# Altium schematic sheet CC3100_click_v100.SchDoc
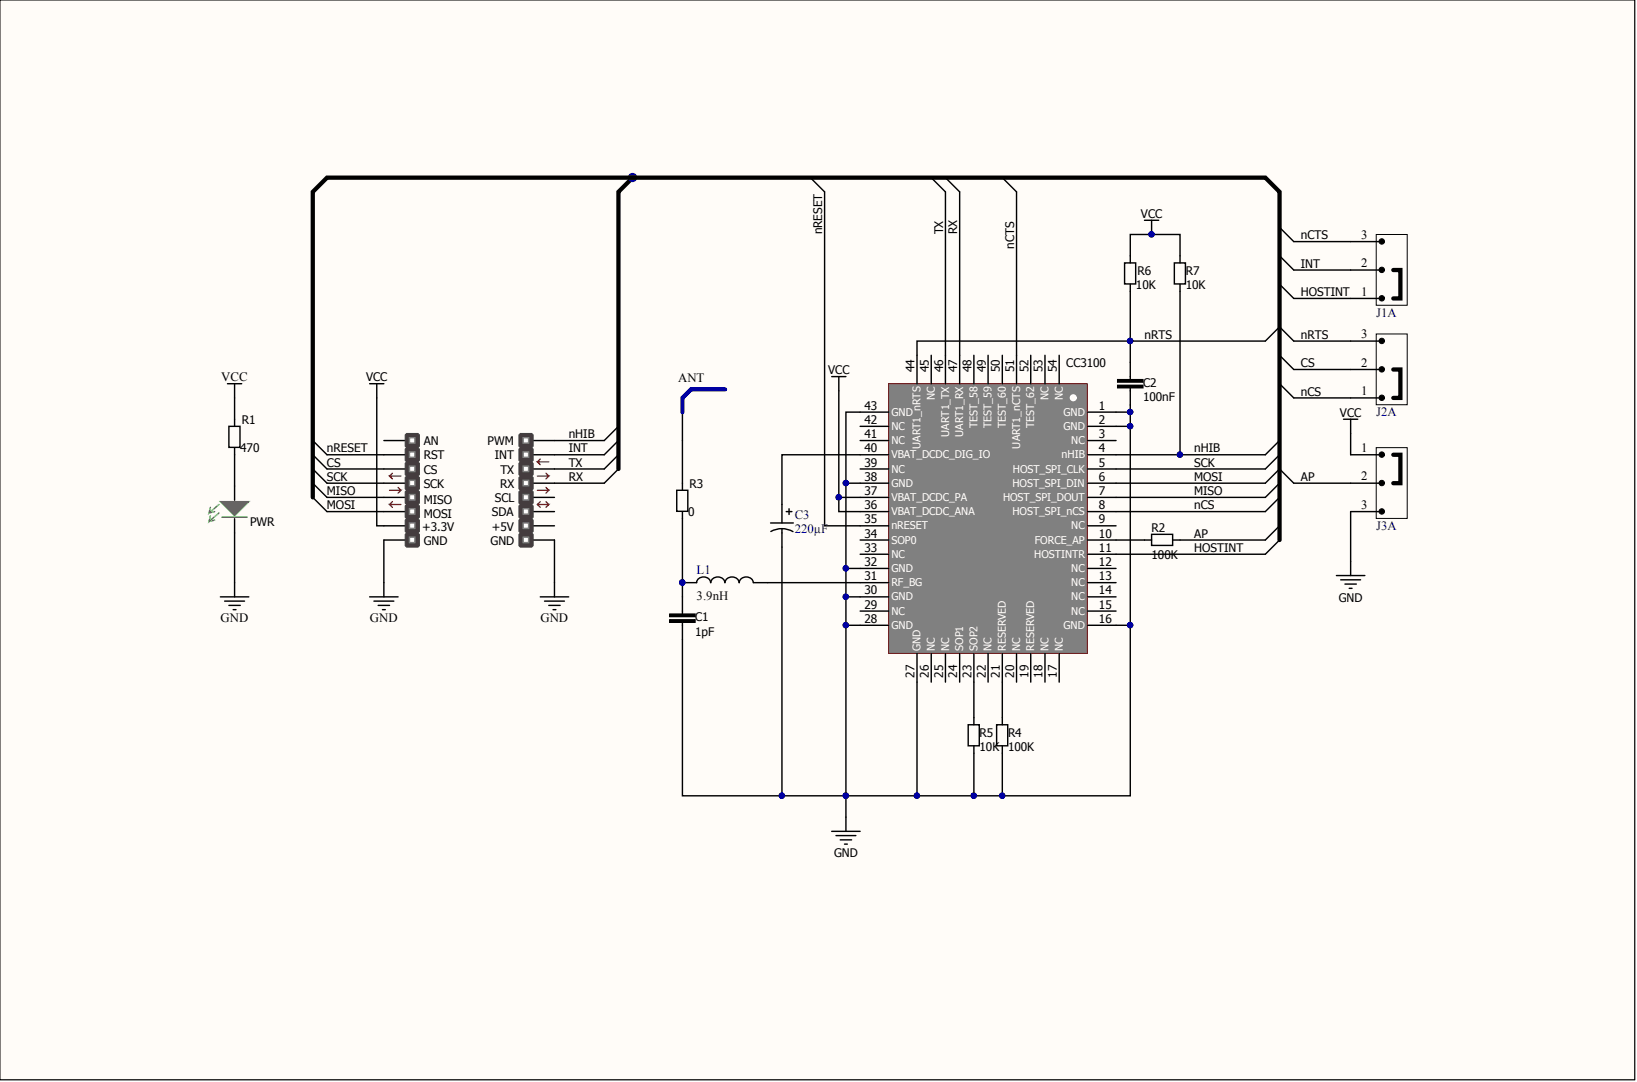
[diagram p0: CC3100_click_v100.SchDoc]

## Parts
ANT  ANT Z=50ohm  [ANTENNA 2450AT45A100]  (value not drawn)
C1  1pF  [0603C]
C2  100nF  [0805_C_V3]
C3  220µF  [CAPMP7343X310N-TypeD]
CC3100  (no value)  [CC3100_MOD V2]
J1  M1X3  [0805 DUAL v2]  (value not drawn)  (part A)
J2  M1X3  [0805 DUAL]  (value not drawn)  (part A)
J3  M1X3  [0805 DUAL v2]  (value not drawn)  (part A)
L1  3.9nH  [0603R]
MIKROBUS?  MIKROBUS DEVICE CONN.  [MIKRO_BUS_LARGE]  (value not drawn)
PWR  LED  [0805 - LED]  (value not drawn)
R1  470  [0805_R_V3]
R2  100K  [0805_R_V3]
R3  0  [0603R]
R4  100K  [0805_C_V3]
R5  10K  [0805_R_V3]
R6  10K  [0805_R_V3]
R7  10K  [0805_R_V3]

## Nets
GND: C1.2 C2.2 C3.2 CC3100.1(GND) CC3100.2(GND) CC3100.16(GND) CC3100.27(GND) CC3100.28(GND) CC3100.30(GND) CC3100.32(GND) CC3100.38(GND) CC3100.43(GND) J3.3 MIKROBUS?.8(GND) MIKROBUS?.9(GND) PWR.2 R4.2 R5.2
VCC: CC3100.36(VBAT_DCDC_ANA) CC3100.37(VBAT_DCDC_PA) J3.1 MIKROBUS?.7(+3.3V) R1.2 R6.1 R7.1
AP: J3.2 R2.1
CS: J2.2 MIKROBUS?.3(CS)
HOSTINT: CC3100.11(HOSTINTR) J1.1
INT: J1.2 MIKROBUS?.15(INT)
MISO: CC3100.7(HOST_SPI_DOUT) MIKROBUS?.5(MISO)
MOSI: CC3100.6(HOST_SPI_DIN) MIKROBUS?.6(MOSI)
NCS: CC3100.8(HOST_SPI_nCS) J2.1
NCTS: CC3100.51(UART1_nCTS) J1.3
NHIB: CC3100.4(nHIB) MIKROBUS?.16(PWM) R7.2
NRESET: CC3100.35(nRESET) MIKROBUS?.2(RST)
NRTS: C2.1 CC3100.44(UART1_nRTS) J2.3 R6.2
RX: CC3100.47(UART1_RX) MIKROBUS?.13(RX)
SCK: CC3100.5(HOST_SPI_CLK) MIKROBUS?.4(SCK)
TX: CC3100.46(UART1_TX) MIKROBUS?.14(TX)
NetANT_1: ANT.1 R3.2
NetC1_1: C1.1 L1.1 R3.1
NetC3_1: C3.1 CC3100.40(VBAT_DCDC_DIG_IO)
NetCC3100_10: CC3100.10(FORCE_AP) R2.2
NetCC3100_21: CC3100.21(RESERVED) R4.1
NetCC3100_23: CC3100.23(SOP2) R5.1
NetCC3100_31: CC3100.31(RF_BG) L1.2
NetPWR_1: PWR.1 R1.1
N00008:

Not connected: CC3100 3(NC) 9(NC) 12(NC) 13(NC) 14(NC) 15(NC) 17(NC) 18(NC) 19(RESERVED) 20(NC) 22(NC) 24(SOP1) 25(NC) 26(NC) 29(NC) 33(NC) 34(SOP0) 39(NC) 41(NC) 42(NC) 45(NC) 48(TEST_58) 49(TEST_59) 50(TEST_60) 52(TEST_62) 53(NC) 54(NC); MIKROBUS? 1(AN) 10(+5V) 11(SDA) 12(SCL)

## Library data of the parts
ANT = ANTENNA: Generic Antenna; Component Kind: Standard; Library Name: CC3100_click_v100.SCHLIB
C1 C2 = CAP NP: Component Kind: Standard; Library Name: CC3100_click_v100.SCHLIB; SMD: Yes
C3 = 2911010048785: CAP TANT POLY 220UF 10V 2917; Manufacturer: Panasonic Electronic Components; Manufacturer Part Number: 10TPE220ML; Assembly: SMD; Database Table Name: capacitors; id: 13; item_id: 5559; Tolerance: ±20%; Barcode: 2911010048785; Capacitance: 220µF; Category: Capacitors; Component Kind: Standard; Datasheet URL: http://industrial.panasonic.com/www-cgi/jvcr13pz.cgi?E+CI+4+AAA8005+10TPE220ML+8+WW; Package Case: 2917 (7343 Metric); Part type: Capacitors; Voltage Rated: 10V; Library Name: erp-baza.SVNDbLib
CC3100 = CC3100: Component Kind: Standard
J1-J3 = JUMPER2: Jumper, two states, 1x3; Component Kind: Standard; Library Name: SCH_Rega.SchLib
L1 = INDUCTOR1: Component Kind: Standard; SMD: Yes; Library Name: SCH_Rega.SchLib
MIKROBUS? = MIKROBUS DEVICE CONN.: mikroBUS Target Header; Component Kind: Standard; Library Name: SCH_Rega.SchLib
R1-R7 = RES: Resistor; Component Kind: Standard; SMD: Yes; Library Name: template_click_v100.SCHLIB
PWR = LED: Component Kind: Standard; SMD: Yes; Library Name: template_click_v100.SCHLIB
